# Altium schematic sheet Channels [3,4].SchDoc("Channels [3,4]")
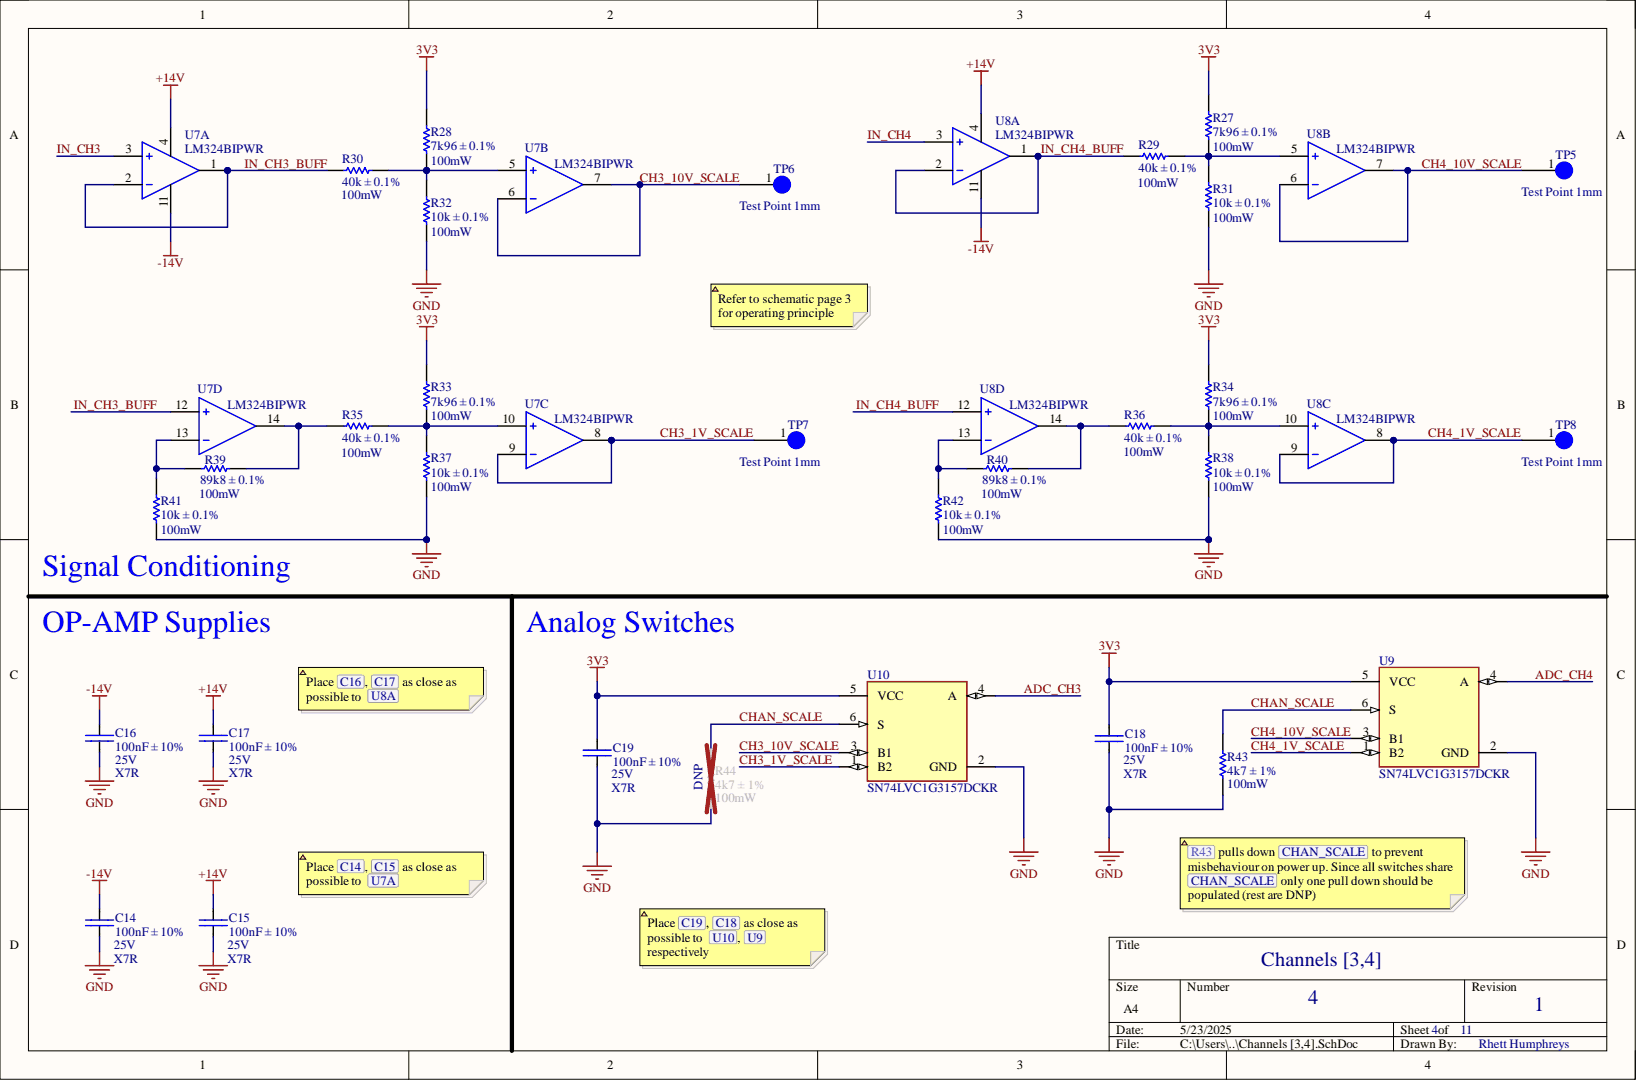
[diagram p0: Channels [3,4].SchDoc]

## Parts
C14  100nF ± 10%  [CAP-0603]
C15  100nF ± 10%  [CAP-0603]
C16  100nF ± 10%  [CAP-0603]
C17  100nF ± 10%  [CAP-0603]
C18  100nF ± 10%  [CAP-0603]
C19  100nF ± 10%  [CAP-0603]
R27  7k96 ± 0.1%  [RES-0603]
R28  7k96 ± 0.1%  [RES-0603]
R29  40k ± 0.1%  [RES-0603]
R30  40k ± 0.1%  [RES-0603]
R31  10k ± 0.1%  [RES-0603]
R32  10k ± 0.1%  [RES-0603]
R33  7k96 ± 0.1%  [RES-0603]
R34  7k96 ± 0.1%  [RES-0603]
R35  40k ± 0.1%  [RES-0603]
R36  40k ± 0.1%  [RES-0603]
R37  10k ± 0.1%  [RES-0603]
R38  10k ± 0.1%  [RES-0603]
R39  89k8 ± 0.1%  [RES-0603]
R40  89k8 ± 0.1%  [RES-0603]
R41  10k ± 0.1%  [RES-0603]
R42  10k ± 0.1%  [RES-0603]
R43  4k7 ± 1%  [RES-0603]
R44  4k7 ± 1%  [RES-0603]
TP5  Test Point 1mm  [Test Point 1mm]
TP6  Test Point 1mm  [Test Point 1mm]
TP7  Test Point 1mm  [Test Point 1mm]
TP8  Test Point 1mm  [Test Point 1mm]
U7  LM324BIPWR  [FP-PW0014A-MFG]  (parts A,B,C,D)
U8  LM324BIPWR  [FP-PW0014A-MFG]  (parts A,B,C,D)
U9  SN74LVC1G3157DCKR  [FP-DCK0006A-IPC_B]
U10  SN74LVC1G3157DCKR  [FP-DCK0006A-IPC_B]

## Nets
3V3: C18.2 C19.2 R27.1 R28.1 R33.1 R34.1 U9.5(VCC) U10.5(VCC)
+14V: C15.2 C17.2 U7.4 U8.4
-14V: C14.2 C16.2 U7.11 U8.11
GND: C14.1 C15.1 C16.1 C17.1 C18.1 C19.1 R31.2 R32.2 R37.2 R38.2 R41.2 R42.2 R43.2 R44.2 U9.2(GND) U10.2(GND)
ADC_CH3: U10.4(A)
ADC_CH4: U9.4(A)
CH3_1V_SCALE: TP7.1 U7.8 U7.9 U10.1(B2)
CH3_10V_SCALE: TP6.1 U7.6 U7.7 U10.3(B1)
CH4_1V_SCALE: TP8.1 U8.8 U8.9 U9.1(B2)
CH4_10V_SCALE: TP5.1 U8.6 U8.7 U9.3(B1)
CHAN_SCALE: R43.1 R44.1 U9.6(S) U10.6(S)
IN_CH3: U7.3
IN_CH3_BUFF: R30.2 U7.1 U7.2 U7.12
IN_CH4: U8.3
IN_CH4_BUFF: R29.2 U8.1 U8.2 U8.12
NetR27_2: R27.2 R29.1 R31.1 U8.5
NetR28_2: R28.2 R30.1 R32.1 U7.5
NetR33_2: R33.2 R35.1 R37.1 U7.10
NetR34_2: R34.2 R36.1 R38.1 U8.10
NetR35_2: R35.2 R39.1 U7.14
NetR36_2: R36.2 R40.1 U8.14
NetR39_2: R39.2 R41.1 U7.13
NetR40_2: R40.2 R42.1 U8.13

## Library data of the parts
C14-C19 = CAP-0603: Capacitor chip unpolarized. 0603; Component Kind: Standard; Library Name: Master.SchLib
R27-R44 = RES-0603: Resistor chip. 0603; Component Kind: Standard; Library Name: Master.SchLib
U7 U8 = CMP-00370-131314463-1: LM324BIPWR Quad, 36-V, 1.2-MHz 3-mV offset voltage operational amplifier 14-TSSOP -40 to 85; Manufacturer Lifecycle Status: ACTIVE; Case/Package: TSSOP; Component Kind: Standard; Height: 1.2mm; Length: 5mm; Library Name: Altium Content Vault; Lifecycle Status: Production; NexarPartId: 131314463; Number of Pins: 14; Thickness: 1mm; Width: 4.4mm
U9 U10 = CMP-0859-00516-4: IC SWITCH SPDT SC70-6; Bandwidth: 300MHz; High Level Output Current: 128mA; Max Supply Current: 10uA; Max Supply Voltage: 5.5V; Min Supply Voltage: 1.65V; Number of Bits: 1; Number of Outputs: 2; On-State Resistance: 15R; Operating Supply Current: 1uA; Polarity: Non-Inverting; Propagation Delay: 300ps; Supply Type: Single; Turn-Off Delay Time: 3.8ns; Turn-On Delay Time: 5.7ns; Case/Package: SC; Component Kind: Standard; Contact Plating: Gold; Height: 0.9mm; Lead Free: Lead Free; Length: 2mm; Library Name: Altium Content Vault; Low Level Output Current: 128mA; Max Operating Temperature: 125°C; Min Operating Temperature: -40°C; Mount: Surface Mount; Nominal Supply Current: 10uA; Number of Channels: 1; Number of Inputs: 1; Number of Pins: 6; Package Quantity: 3000; Packaging: Tape and Reel; Radiation Hardening: No; REACH SVHC: No SVHC; RoHS Compliant: Yes; Termination: SMD/SMT; Throw Configuration: SPDT; Weight: 8.8E-05oz; Width: 1.25mm
TP5-TP8 = Test Point 1mm: Component Kind: Standard; Library Name: Master.SchLib
